# Altium schematic sheet EIT_MUXERS.SchDoc
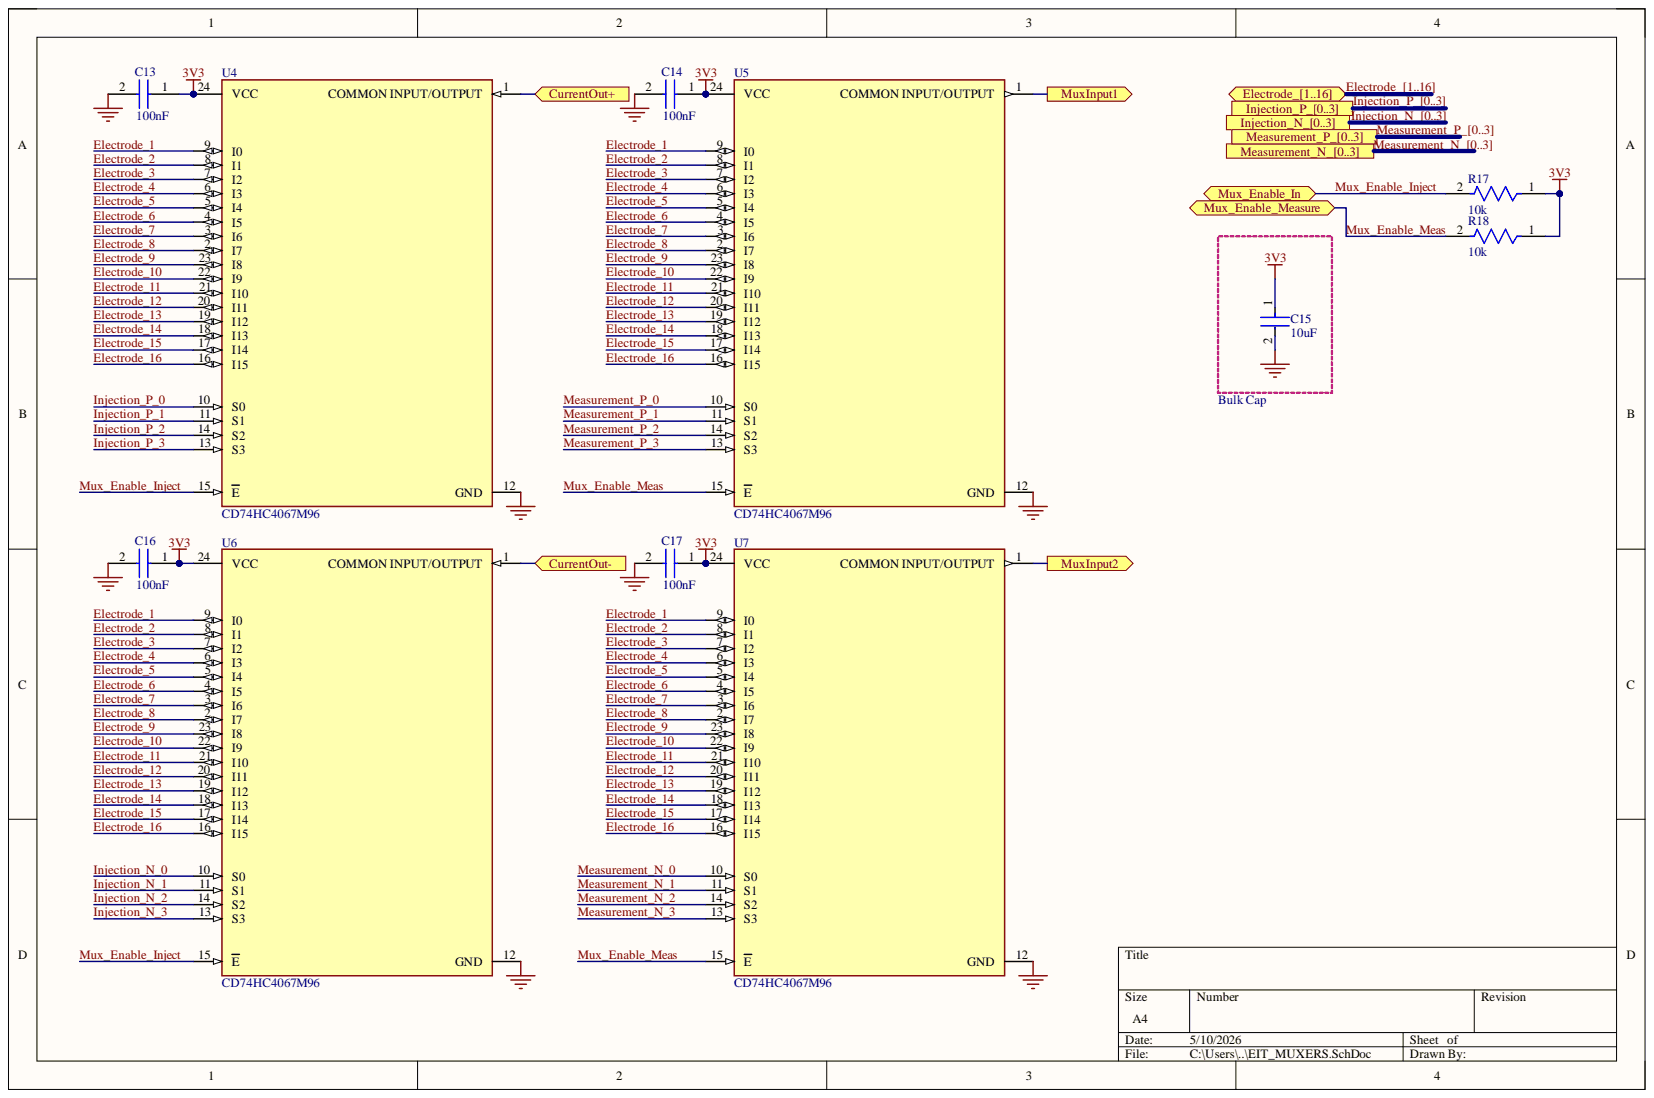
[diagram p0: EIT_MUXERS.SchDoc]

## Parts
C13  100nF  [CAPC1608X90N]
C14  100nF  [CAPC1608X90N]
C15  10uF  [FP-CL21-IPC_B]
C16  100nF  [CAPC1608X90N]
C17  100nF  [CAPC1608X90N]
R17  10k  [FP-RT0603-MFG]
R18  10k  [FP-RT0603-MFG]
U4  CD74HC4067M96  [FP-DW0024A-MFG]
U5  CD74HC4067M96  [FP-DW0024A-MFG]
U6  CD74HC4067M96  [FP-DW0024A-MFG]
U7  CD74HC4067M96  [FP-DW0024A-MFG]

## Ports
CurrentOut+, CurrentOut-, Electrode_1, Electrode_2, Electrode_3, Electrode_4, Electrode_5, Electrode_6, Electrode_7, Electrode_8, Electrode_9, Electrode_10, Electrode_11, Electrode_12, Electrode_13, Electrode_14, Electrode_15, Electrode_16, Electrode_[1..16], Injection_N_0, Injection_N_1, Injection_N_2, Injection_N_3, Injection_N_[0..3], Injection_P_0, Injection_P_1, Injection_P_2, Injection_P_3, Injection_P_[0..3], Measurement_N_0, Measurement_N_1, Measurement_N_2, Measurement_N_3, Measurement_N_[0..3], Measurement_P_0, Measurement_P_1, Measurement_P_2, Measurement_P_3, Measurement_P_[0..3], Mux_Enable_In, Mux_Enable_Measure, MuxInput1, MuxInput2

## Nets
3V3: C13.1 C14.1 C15.1 C16.1 C17.1 R17.1 R18.1 U4.24(VCC) U5.24(VCC) U6.24(VCC) U7.24(VCC)
GND: C13.2 C14.2 C15.2 C16.2 C17.2 U4.12(GND) U5.12(GND) U6.12(GND) U7.12(GND)
Electrode_1: U4.9(I0) U5.9(I0) U6.9(I0) U7.9(I0) ; port Electrode_[1..16]  [also labelled Electrode_]
Electrode_2: U4.8(I1) U5.8(I1) U6.8(I1) U7.8(I1) ; port Electrode_[1..16]  [also labelled Electrode_]
Electrode_3: U4.7(I2) U5.7(I2) U6.7(I2) U7.7(I2) ; port Electrode_[1..16]  [also labelled Electrode_]
Electrode_4: U4.6(I3) U5.6(I3) U6.6(I3) U7.6(I3) ; port Electrode_[1..16]  [also labelled Electrode_]
Electrode_5: U4.5(I4) U5.5(I4) U6.5(I4) U7.5(I4) ; port Electrode_[1..16]  [also labelled Electrode_]
Electrode_6: U4.4(I5) U5.4(I5) U6.4(I5) U7.4(I5) ; port Electrode_[1..16]  [also labelled Electrode_]
Electrode_7: U4.3(I6) U5.3(I6) U6.3(I6) U7.3(I6) ; port Electrode_[1..16]  [also labelled Electrode_]
Electrode_8: U4.2(I7) U5.2(I7) U6.2(I7) U7.2(I7) ; port Electrode_[1..16]  [also labelled Electrode_]
Electrode_9: U4.23(I8) U5.23(I8) U6.23(I8) U7.23(I8) ; port Electrode_[1..16]  [also labelled Electrode_]
Electrode_10: U4.22(I9) U5.22(I9) U6.22(I9) U7.22(I9) ; port Electrode_[1..16]  [also labelled Electrode_]
Electrode_11: U4.21(I10) U5.21(I10) U6.21(I10) U7.21(I10) ; port Electrode_[1..16]  [also labelled Electrode_]
Electrode_12: U4.20(I11) U5.20(I11) U6.20(I11) U7.20(I11) ; port Electrode_[1..16]  [also labelled Electrode_]
Electrode_13: U4.19(I12) U5.19(I12) U6.19(I12) U7.19(I12) ; port Electrode_[1..16]  [also labelled Electrode_]
Electrode_14: U4.18(I13) U5.18(I13) U6.18(I13) U7.18(I13) ; port Electrode_[1..16]  [also labelled Electrode_]
Electrode_15: U4.17(I14) U5.17(I14) U6.17(I14) U7.17(I14) ; port Electrode_[1..16]  [also labelled Electrode_]
Electrode_16: U4.16(I15) U5.16(I15) U6.16(I15) U7.16(I15) ; port Electrode_[1..16]  [also labelled Electrode_]
Injection_N_0: U6.10(S0) ; port Injection_N_[0..3]  [also labelled Injection_N_]
Injection_N_1: U6.11(S1) ; port Injection_N_[0..3]  [also labelled Injection_N_]
Injection_N_2: U6.14(S2) ; port Injection_N_[0..3]  [also labelled Injection_N_]
Injection_N_3: U6.13(S3) ; port Injection_N_[0..3]  [also labelled Injection_N_]
Injection_P_0: U4.10(S0) ; port Injection_P_[0..3]  [also labelled Injection_P_]
Injection_P_1: U4.11(S1) ; port Injection_P_[0..3]  [also labelled Injection_P_]
Injection_P_2: U4.14(S2) ; port Injection_P_[0..3]  [also labelled Injection_P_]
Injection_P_3: U4.13(S3) ; port Injection_P_[0..3]  [also labelled Injection_P_]
Measurement_N_0: U7.10(S0) ; port Measurement_N_[0..3]  [also labelled Measurement_N_]
Measurement_N_1: U7.11(S1) ; port Measurement_N_[0..3]  [also labelled Measurement_N_]
Measurement_N_2: U7.14(S2) ; port Measurement_N_[0..3]  [also labelled Measurement_N_]
Measurement_N_3: U7.13(S3) ; port Measurement_N_[0..3]  [also labelled Measurement_N_]
Measurement_P_0: U5.10(S0) ; port Measurement_P_[0..3]  [also labelled Measurement_P_]
Measurement_P_1: U5.11(S1) ; port Measurement_P_[0..3]  [also labelled Measurement_P_]
Measurement_P_2: U5.14(S2) ; port Measurement_P_[0..3]  [also labelled Measurement_P_]
Measurement_P_3: U5.13(S3) ; port Measurement_P_[0..3]  [also labelled Measurement_P_]
Mux_Enable_Inject: R17.2 U4.15(E) U6.15(E) ; port Mux_Enable_In
Mux_Enable_Meas: R18.2 U5.15(E) U7.15(E) ; port Mux_Enable_Measure
MuxInput1: U5.1(COMMON_INPUT/OUTPUT) ; port MuxInput1
MuxInput2: U7.1(COMMON_INPUT/OUTPUT) ; port MuxInput2
NetU4_1: U4.1(COMMON_INPUT/OUTPUT)
NetU6_1: U6.1(COMMON_INPUT/OUTPUT)

## Library data of the parts
C13 C14 C16 C17 = CL10B104KB8NNNC: Capacitor; Manufacturer_Name: SAMSUNG; Arrow Part Number: CL10B104KB8NNNC; Arrow Price/Stock: https://www.arrow.com/en/products/cl10b104kb8nnnc/samsung-electro-mechanics?utm_currency=USD&region=nac; Component Kind: Standard; Datasheet Link: https://datasheet.datasheetarchive.com/originals/distributors/Datasheets-DGA10/2408969.pdf; Height: 0.9mm; Manufacturer_Part_Number: CL10B104KB8NNNC; Mouser Part Number: 187-CL10B104KB8NNNC; Mouser Price/Stock: https://www.mouser.co.uk/ProductDetail/Samsung-Electro-Mechanics/CL10B104KB8NNNC?qs=349EhDEZ59rvGc2rLwVOdA%3D%3D; Simulation: C_Capacitor_Default
C15 = CMP-2000-06102-2: CL21 Series 0805 10uF 16V ±10% Tolerance X5R Multilayer Ceramic Chip Capacitor; Dielectric: X7R; Capacitance: 10uF; Case Code (Imperial): 0805; Case Code (Metric): 2012; Case/Package: 0805; Component Kind: Standard; Depth: 1.25mm; Height: 1.25mm; Lead Free: Lead Free; Length: 2mm; Library Name: Altium Content Vault; Max Operating Temperature: 85°C; Min Operating Temperature: -55°C; Mount: Surface Mount; Package Quantity: 1; Packaging: Tape and Reel; Radiation Hardening: No; Thickness: 0.055inch; Tolerance: 10%; Voltage: 16V; Voltage Rating: 16V; Voltage Rating (DC): 16V; Width: 0.049inch
R17 R18 = CMP-03412-000619-1: RES 10.0K OHM 1/10W .1% SMD 0603; Manufacturer: Yageo; Manufacturer Part Number: RT0603BRD0710KL; Power Rating: 0.1W; Resistance: 10KΩ; Temperature Coefficient: 25 ppm/°C; Tolerance: 0.001; Voltage Rating: 75V; Automotive: No; Category: Res; Component Kind: Standard; ComponentLink2Description: Datasheet; ComponentLink2URL: http://www.yageo.com/documents/recent/PYu-RT_1-to-0.01_RoHS_L_9.pdf; Composition: Thin Film; Device Class L1: Passive Components; Device Class L2: Resistors; Device Class L3: Chip SMD Resistors; Digikey Description: RES 10.0K OHM 1/10W .1% SMD 0603; Footprint Url: http://www.yageo.com/exep/pages/download/literatures/PYu-R_Mount_10.pdf; Height: 0.55mm; Ipc Land Pattern Name: RESC16080X45; Lead Free: Yes; Library Name: Altium Content Vault; Mouser Description: Thin Film Resistors - SMD 10K ohm 0.1% 1/10W; Octopart: https://octopart.com/rt0603brd0710kl-yageo-1399221; Package: RT0603; RoHS: TRUE; Series: RT; Simulation: R_Resistor_Default; Temperature: -55°C to +155°C; Temperature Range High: +155°C; Temperature Range Low: -55°C
U4-U7 = CMP-04901-000007-1: IC MUX/DEMUX 1X16 24SOIC; Manufacturer: Texas Instruments; Logic Function Desc: Non-Inverting; Max Junction Temp: +150°C; Max Supply Voltage: 6V; Min Supply Voltage: 2V; Number Of Circuits: 1; Number Of Inputs: 16; Propagation Delay: 6ns; Quiescent Current: 8uA; Standoff Height: 0.1mm; Ambient Temperature Range High: +125°C; Ambient Temperature Range Low: -55°C; Automotive: No; Category: IC; Component Kind: Standard; ComponentLink2Description: Datasheet; ComponentLink2URL: http://www.ti.com/lit/ds/symlink/cd74hc4067.pdf; Device Class L1: Integrated Circuits (ICs); Device Class L2: Logic ICs; Device Class L3: Multiplexers; Digikey Description: IC MUX/DEMUX 1X16 24SOIC; Height: 2.65mm; Ipc Land Pattern Name: SOIC127P1030X265-24; Lead Free: Yes; Library Name: Altium Content Vault; Manufacturer Part Number: CD74HC4067M96; Mouser Description: Multiplexer Switch ICs Hi-Spd CMOS 16Ch Anl Mltplxr/Demltplxr; Number Of Outputs: 1; Octopart: https://octopart.com/cd74hc4067m96-texas+instruments-722802; Package: DW0024A; RoHS: TRUE; Temperature: -55°C to +125°C; Temperature Range High: +125°C; Temperature Range Low: -55°C
